# Altium schematic sheet extBoard_sheet5_connectors.SchDoc
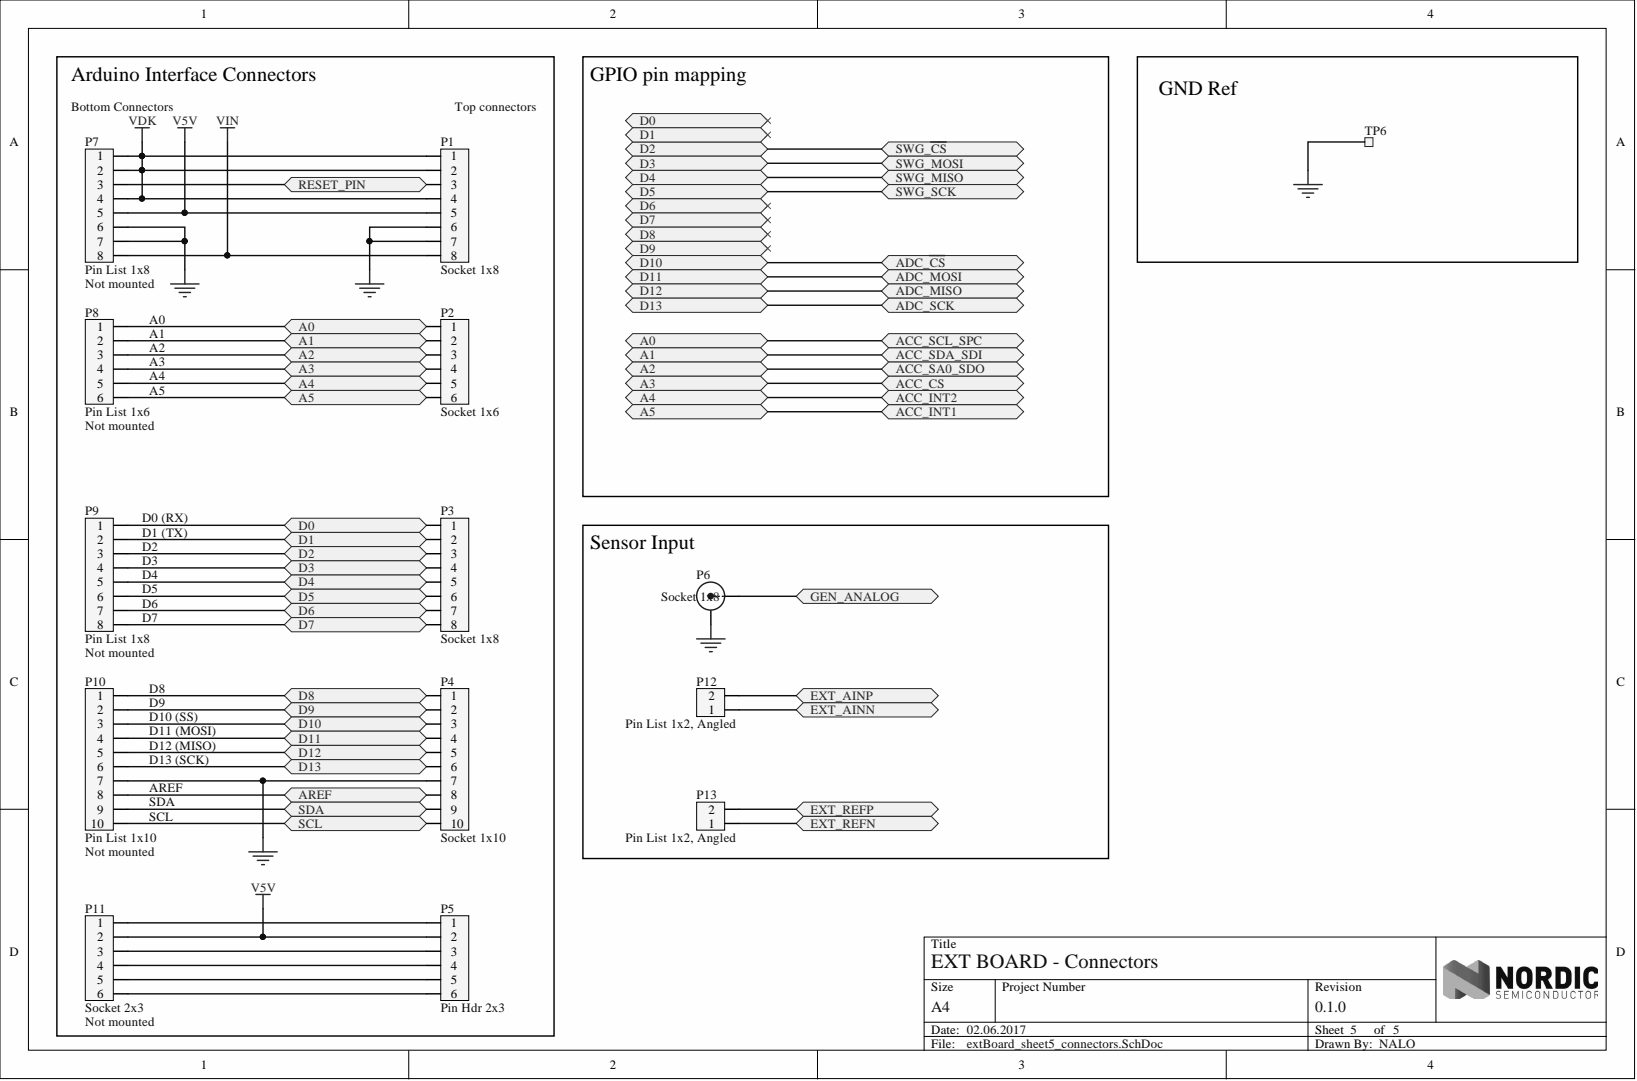
[diagram p0: extBoard_sheet5_connectors.SchDoc]

## Parts
P1  Socket 1x8  [SOCKET_1x8_SMD]
P2  Socket 1x6  [SOCKET_1x6_SMD]
P3  Socket 1x8  [SOCKET_1x8_SMD]
P4  Socket 1x10  [SOCKET_1x10_SMD]
P5  Pin Hdr 2x3  [HDR_2x3-SMD]
P6  Socket 1x8  [BNC_RA CON]
P7  Pin List 1x8  Assembly: Not mounted  [LIST_1x8_SMD]
P8  Pin List 1x6  Assembly: Not mounted  [LIST_1x6_SMD]
P9  Pin List 1x8  Assembly: Not mounted  [LIST_1x8_SMD]
P10  Pin List 1x10  Assembly: Not mounted  [LIST_1x10_SMD]
P11  Socket 2x3  Assembly: Not mounted  [SOCKET_2x3_SMD-2.54mm-bottom]
P12  Pin List 1x2, Angled  [LIST_ANG_1x2-SMD-2.54MM]
P13  Pin List 1x2, Angled  [LIST_ANG_1x2-SMD-2.54MM]
TP6  TP  [TESTPAD-TH]  (no BOM)

## Ports
A0, A1, A2, A3, A4, A5, ACC_CS, ACC_INT1, ACC_INT2, ACC_SA0_SDO, ACC_SCL_SPC, ACC_SDA_SDI, ADC_MISO, ADC_MOSI, ADC_SCK, ADC_~{CS}, AREF, D0, D1, D2, D3, D4, D5, D6, D7, D8, D9, D10, D11, D12, D13, EXT_AINN, EXT_AINP, EXT_REFN, EXT_REFP, GEN_ANALOG, RESET_PIN, SCL, SDA, SWG_MISO, SWG_MOSI, SWG_SCK, SWG_~{CS}

## Nets
GND: P1.6 P1.7 P4.7 P6.2 P7.6 P7.7 P10.7 TP6.1
V5V: P1.5 P5.2 P7.5 P11.2
VDK: P1.1 P1.2 P1.4 P7.1 P7.2 P7.4
VIN: P1.8 P7.8
A0: P2.1 P8.1 ; port A0, port ACC_SCL_SPC
A1: P2.2 P8.2 ; port A1, port ACC_SDA_SDI
A2: P2.3 P8.3 ; port A2, port ACC_SA0_SDO
A3: P2.4 P8.4 ; port A3, port ACC_CS
A4: P2.5 P8.5 ; port A4, port ACC_INT2
A5: P2.6 P8.6 ; port A5, port ACC_INT1
ADC_~{CS}: P4.3 P10.3 ; port ADC_~{CS}, port D10
ADC_MISO: P4.5 P10.5 ; port ADC_MISO, port D12
ADC_MOSI: P4.4 P10.4 ; port ADC_MOSI, port D11
ADC_SCK: P4.6 P10.6 ; port ADC_SCK, port D13
AREF: P4.8 P10.8 ; port AREF
D0: P3.1 P9.1 ; port D0
D1: P3.2 P9.2 ; port D1
D2: P3.3 P9.3 ; port D2, port SWG_~{CS}
D3: P3.4 P9.4 ; port D3, port SWG_MOSI
D4: P3.5 P9.5 ; port D4, port SWG_MISO
D5: P3.6 P9.6 ; port D5, port SWG_SCK
D6: P3.7 P9.7 ; port D6
D7: P3.8 P9.8 ; port D7
D8: P4.1 P10.1 ; port D8
D9: P4.2 P10.2 ; port D9
EXT_AINN: P12.1 ; port EXT_AINN
EXT_AINP: P12.2 ; port EXT_AINP
EXT_REFN: P13.1 ; port EXT_REFN
EXT_REFP: P13.2 ; port EXT_REFP
GEN_ANALOG: P6.1 ; port GEN_ANALOG
RESET_PIN: P1.3 P7.3 ; port RESET_PIN
SCL: P4.10 P10.10 ; port SCL
SDA: P4.9 P10.9 ; port SDA
NetP5_1: P5.1 P11.1
NetP5_3: P5.3 P11.3
NetP5_4: P5.4 P11.4
NetP5_5: P5.5 P11.5
NetP5_6: P5.6 P11.6

## Library data of the parts
P1 P3 = Socket 1x8 SMD: Socket 1x8, 2.54mm (100mil), SMD; 2nd source part number: SSM-108-F-SV-P-TR; Manufacturer: W-CON; Manufacturer Part Number: 2171-108MS0CUNR1; Artikkelnr Noca: 55030002; Artikkelnr Simpro: N.A.; Database Table Name: Connectors$; 2nd source Supplier: Samtec; Component Kind: Standard; Footprint Doc: SOCKET_1x8_SMD; LatestRevisionDate: 2016-01-22; Library Name: Nordic Passive DB.DbLib; Part Number: Socket 1x8 SMD; Pin Count: 8; Published: 2016-01-22; Supplier: Cabcon; Supplier part number: 2171-108MS0CUNR1; Value: Socket 1x8; Publisher: Nordic Semiconductor
P2 = Socket 1x6 SMD: Socket 1x6, 2.54mm (100mil), SMD; 2nd source part number: SSM-106-F-SV-P-TR; Manufacturer: W-CON; Manufacturer Part Number: 2171-106MS0CUNR1; Artikkelnr Noca: 55030001; Artikkelnr Simpro: N.A.; Database Table Name: Connectors$; 2nd source Supplier: Samtec; Component Kind: Standard; Footprint Doc: SOCKET_1x6_SMD; LatestRevisionDate: 2016-01-22; Library Name: Nordic Passive DB.DbLib; Part Number: Socket 1x6 SMD; Pin Count: 6; Published: 2016-01-22; Supplier: Cabcon; Supplier part number: 2171-106MS0CUNR1; Value: Socket 1x6; Publisher: Nordic Semiconductor
P4 = Socket 1x10 SMD: Socket 1x10, 2.54mm (100mil), SMD; 2nd source part number: SSM-110-F-SV-P-TR; Manufacturer: W-CON; Manufacturer Part Number: 2171-110MS0CUNR1; Artikkelnr Noca: 55030004; Artikkelnr Simpro: N.A.; Database Table Name: Connectors$; 2nd source Supplier: Samtec; Component Kind: Standard; Footprint Doc: SOCKET_1x10_SMD; LatestRevisionDate: 2016-01-22; Library Name: Nordic Passive DB.DbLib; Part Number: Socket 1x10 SMD; Pin Count: 10; Published: 2016-01-22; Supplier: Cabcon; Supplier part number: 2171-110MS0CUNR1; Value: Socket 1x10; Publisher: Nordic Semiconductor
P5 = Pin Header 2x3 SMD: Pin Header 2x3, 2.54mm (100mil) SMD; 2nd source part number: TSM-103-01-L-DV; Manufacturer: W-CON; Manufacturer Part Number: 1125-1203S0M116R1; Artikkelnr Noca: 55010007; Artikkelnr Simpro: N.A.; Database Table Name: Connectors$; 2nd source Supplier: Samtec; Component Kind: Standard; Datasheet: \\hizz\pro\app9717\Datasheet\connectores\W-CON\1125-12XXXXMXXXXX.PDF; Footprint Doc: HDR_2x3-SMD; LatestRevisionDate: 2016-01-22; Library Name: Nordic Passive DB.DbLib; Note: SMD; Part Number: Pin Header 2x3 SMD; Pin Count: 6; Published: 2016-01-22; Supplier: Cabcon; Supplier part number: 1125-1203S0M116R1; Value: Pin Hdr 2x3; Publisher: Nordic Semiconductor
P6 = BNC: BNC Elbow Connector; Component Kind: Standard; LatestRevisionDate: 17-Jul-2002; LatestRevisionNote: Re-released for DXP Platform.; Library Name: Miscellaneous Connectors.IntLib; Signal Integrity: Connector; Publisher: Altium Limited
P7 P9 = Pin List 1x8 SMD: Pin List 1x8, 2.54mm (100mil), SMD; 2nd source part number: TSM-108-01-L-SV-P-TR; Manufacturer: W-CON; Manufacturer Part Number: 1125-1108S0M116R2; Artikkelnr Noca: 55010006; Artikkelnr Simpro: N.A.; Database Table Name: Connectors$; 2nd source Supplier: Samtec; Component Kind: Standard; Datasheet: \\hizz\pro\app9717\Datasheet\connectores\W-CON\1125-11XXXXMXXXXX.pdf; Footprint Doc: LIST_1x8_SMD; LatestRevisionDate: 2016-01-22; Library Name: Nordic Passive DB.DbLib; Part Number: Pin List 1x8 SMD; Pin Count: 8; Published: 2016-01-22; Supplier: Cabcon; Supplier part number: 1125-1108S0M116R2; Value: Pin List 1x8; Publisher: Nordic Semiconductor
P8 = Pin List 1x6 SMD: Pin List 1x6, 2.54mm (100mil), SMD; 2nd source part number: TSM-106-01-L-SV-P-TR; Manufacturer: W-CON; Manufacturer Part Number: 1125-1106S0M116R2; Artikkelnr Noca: 55010026; Artikkelnr Simpro: N.A.; Database Table Name: Connectors$; 2nd source Supplier: Samtec; Component Kind: Standard; Datasheet: \\hizz\pro\app9717\Datasheet\connectores\W-CON\1125-11XXXXMXXXXX.pdf; Footprint Doc: LIST_1x6_SMD; LatestRevisionDate: 2016-03-29; Library Name: Nordic Passive DB.DbLib; Part Number: Pin List 1x6 SMD; Pin Count: 6; Published: 2016-03-29; Supplier: Cabcon; Supplier part number: 1125-1106S0M116R2; Value: Pin List 1x6; Publisher: Nordic Semiconductor
P10 = Pin List 1x10 SMD: Pin List 1x10, 2.54mm (100mil), SMD; 2nd source part number: TSM-110-01-L-SV-P-TR; Manufacturer: W-CON; Manufacturer Part Number: 1125-1110S0M116R2; Artikkelnr Noca: 55010028; Artikkelnr Simpro: N.A.; Database Table Name: Connectors$; 2nd source Supplier: Samtec; Component Kind: Standard; Datasheet: \\hizz\pro\app9717\Datasheet\connectores\W-CON\1125-11XXXXMXXXXX.pdf; Footprint Doc: LIST_1x10_SMD; LatestRevisionDate: 2016-03-29; Library Name: Nordic Passive DB.DbLib; Part Number: Pin List 1x10 SMD; Pin Count: 10; Published: 2016-03-29; Supplier: Cabcon; Supplier part number: 1125-1110S0M116R2; Value: Pin List 1x10; Publisher: Nordic Semiconductor
P11 = Socket 2x3 SMD Bottom: Socket 2x3, 2.54mm (100mil), SMD; 2nd source part number: SSM-103-F-DV-P-TR; Manufacturer: W-CON; Manufacturer Part Number: 2171-203MS0CUNR1; Artikkelnr Noca: 55030010; Artikkelnr Simpro: N.A.; Database Table Name: Connectors$; 2nd source Supplier: Samtec; Component Kind: Standard; Datasheet: \\hizz\pro\app9717\Datasheet\connectores\W-CON\2171-2XXMXXCUNXX.pdf; Footprint Doc: SOCKET_2x3_SMD-2.54mm-bottom; LatestRevisionDate: 2016-03-29; Library Name: Nordic Passive DB.DbLib; Part Number: Socket 2x3 SMD Bottom; Pin Count: 6; Published: 2014-06-10; Supplier: Cabcon; Supplier part number: 2171-203MS0CUNR1; Value: Socket 2x3; Publisher: Nordic Semiconductor
P12 P13 = Pin List Ang 1x2 SMD, 2.54mm: Pin List 1x2, 2.54mm (100mil), Right Angled, SMD; 2nd source part number: TSM-102-01-L-SH-A; Manufacturer: W-CON; Manufacturer Part Number: 1125B-1102S0Z135R2; Artikkelnr Noca: 55010023; Artikkelnr Simpro: N.A.; 2nd source Supplier: Samtec; Component Kind: Standard; Datasheet: \\hizz\pro\app9717\Datasheet\connectores\W-CON\1125B-11XXXXZXXXXX.pdf; Footprint Doc: LIST_ANG_1x2-SMD-2.54MM; LatestRevisionDate: 2016-05-26; Library Name: pca10056.IntLib; Part Number: Pin List Ang 1x2 SMD, 2.54mm; Pin Count: 2; Published: 2016-01-22; Supplier: Cabcon; Supplier part number: 1125B-1102S0Z135R2; Value: Pin List 1x2, Angled; Publisher: Nordic Semiconductor
TP6 = Testpoint TH: Testpoint, through hole; Artikkelnr Noca: N.A.; Artikkelnr Simpro: N.A.; Footprint Doc: TESTPAD-TH; LatestRevisionDate: 2009-12-12; Library Name: pca10056.IntLib; Part Number: Testpoint TH; Pin Count: 1; Published: 2009-12-12; Value: TP; Publisher: Nordic Semiconductor
